# Altium schematic sheet FaultCircuit.SchDoc(FaultCircuit)
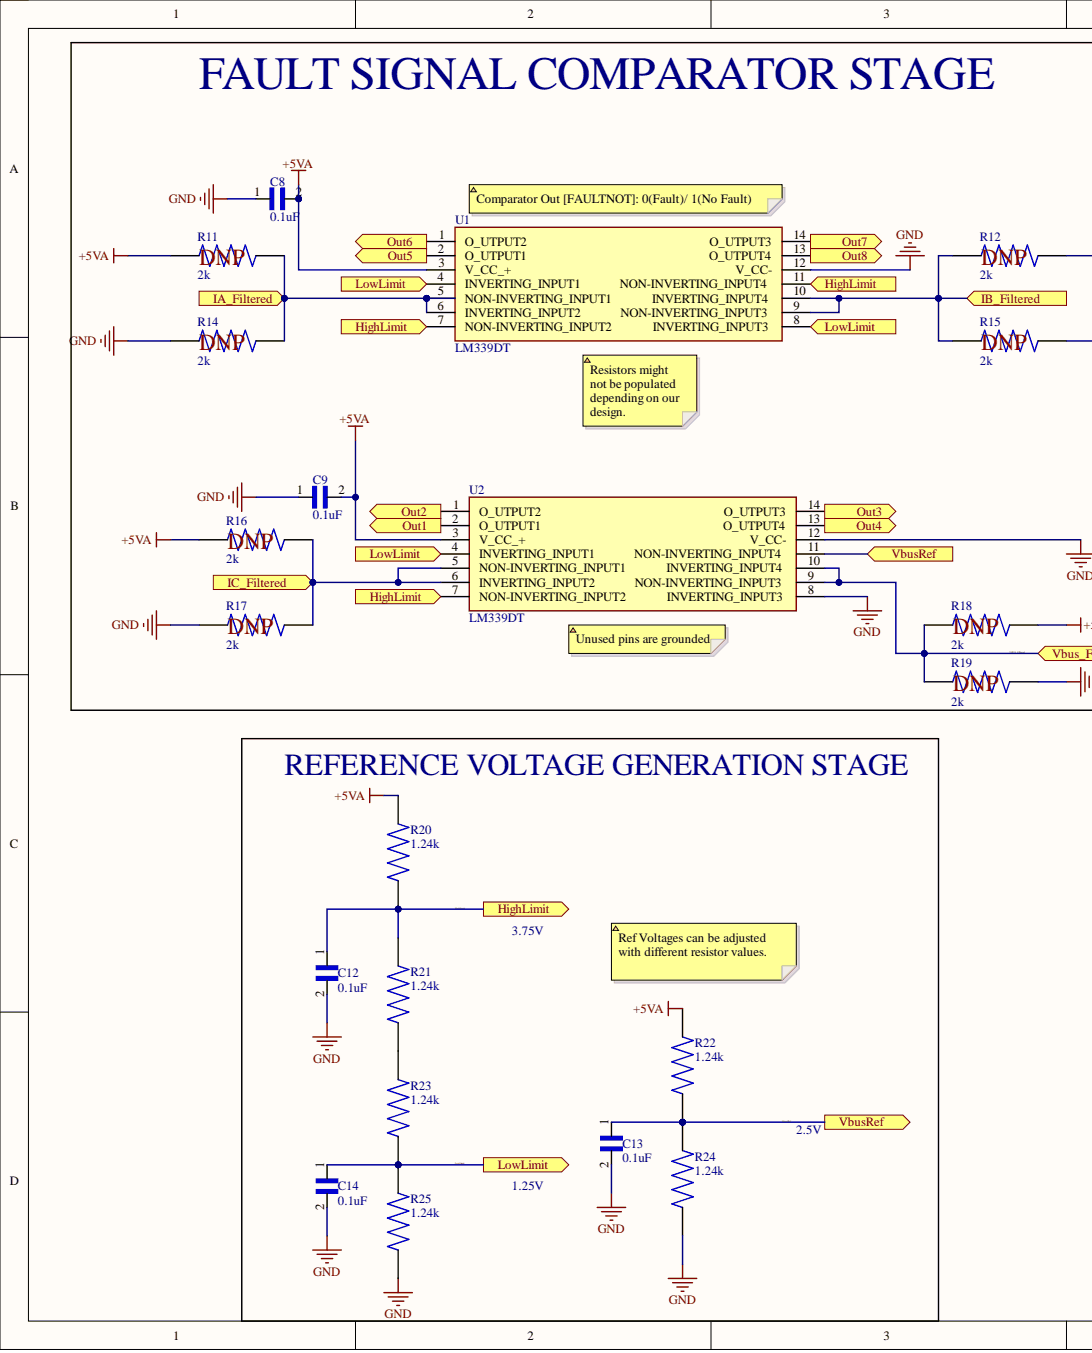
[diagram p0: FaultCircuit.SchDoc, left]
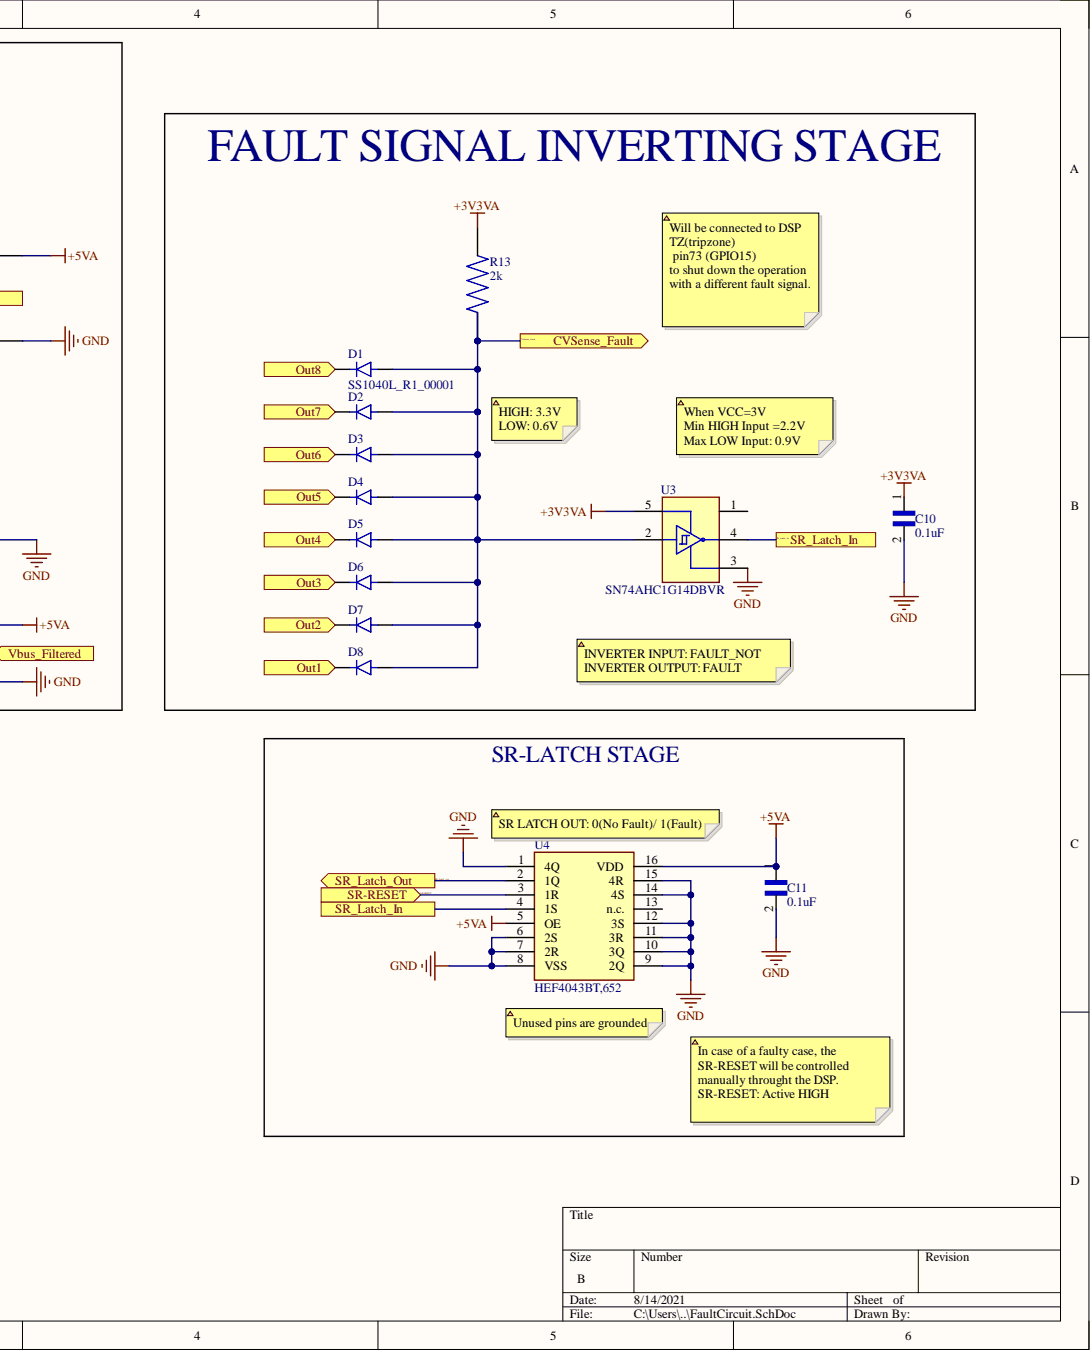
[diagram p1: FaultCircuit.SchDoc, right]

## Parts
C8  0.1uF  [CAP 0805(2012 metric)]
C9  0.1uF  [CAP 0805(2012 metric)]
C10  0.1uF  [CAP 0805(2012 metric)]
C11  0.1uF  [CAP 0805(2012 metric)]
C12  0.1uF  [CAP 0805(2012 metric)]
C13  0.1uF  [CAP 0805(2012 metric)]
C14  0.1uF  [CAP 0805(2012 metric)]
D1  SS1040L_R1_00001  [SOD123]
D2  SS1040L_R1_00001  [SOD123]
D3  SS1040L_R1_00001  [SOD123]
D4  SS1040L_R1_00001  [SOD123]
D5  SS1040L_R1_00001  [SOD123]
D6  SS1040L_R1_00001  [SOD123]
D7  SS1040L_R1_00001  [SOD123]
D8  SS1040L_R1_00001  [SOD123]
R11  2k  [RES 0805(2012 metric)]
R12  2k  [RES 0805(2012 metric)]
R13  2k  [RES 0805(2012 metric)]
R14  2k  [RES 0805(2012 metric)]
R15  2k  [RES 0805(2012 metric)]
R16  2k  [RES 0805(2012 metric)]
R17  2k  [RES 0805(2012 metric)]
R18  2k  [RES 0805(2012 metric)]
R19  2k  [RES 0805(2012 metric)]
R20  1.24k  [RES 0805(2012 metric)]
R21  1.24k  [RES 0805(2012 metric)]
R22  1.24k  [RES 0805(2012 metric)]
R23  1.24k  [RES 0805(2012 metric)]
R24  1.24k  [RES 0805(2012 metric)]
R25  1.24k  [RES 0805(2012 metric)]
U1  LM339DT  [SOIC127P600X175-14N]
U2  LM339DT  [SOIC127P600X175-14N]
U3  SN74AHC1G14DBVR  [SN74AHC1G14DBVR]
U4  HEF4043BT,652  [SOIC127P600X175-16N]

## Ports
CVSense_Fault, HighLimit, IA_Filtered, IB_Filtered, IC_Filtered, LowLimit, Out1, Out2, Out3, Out4, Out5, Out6, Out7, Out8, SR-RESET, SR_Latch_In, SR_Latch_Out, Vbus_Filtered, VbusRef

## Nets
+3V3VA: C10.1 R13.2 U3.5
+5VA: C8.2 C9.2 C11.1 R11.1 R12.2 R16.1 R18.2 R20.2 R22.2 U1.3(V_CC_+) U2.3(V_CC_+) U4.5(OE) U4.16(VDD)
GND: C8.1 C9.1 C10.2 C11.2 C12.2 C13.2 C14.2 R14.1 R15.2 R17.1 R19.2 R24.1 R25.1 U1.12(V_CC-) U2.8(INVERTING_INPUT3) U2.12(V_CC-) U3.3 U4.1(4Q) U4.6(2S) U4.7(2R) U4.8(VSS) U4.9(2Q) U4.10(3Q) U4.11(3R) U4.12(3S) U4.14(4S) U4.15(4R)
CVSense_Fault: D1.2 D2.2 D3.2 D4.2 D5.2 D6.2 D7.2 D8.2 R13.1 U3.2 ; port CVSense_Fault
HighLimit: C12.1 R20.1 R21.2 U1.7(NON-INVERTING_INPUT2) U1.11(NON-INVERTING_INPUT4) U2.7(NON-INVERTING_INPUT2) ; port HighLimit
IA_Filtered: R11.2 R14.2 U1.5(NON-INVERTING_INPUT1) U1.6(INVERTING_INPUT2) ; port IA_Filtered
IB_Filtered: R12.1 R15.1 U1.9(NON-INVERTING_INPUT3) U1.10(INVERTING_INPUT4) ; port IB_Filtered
IC_Filtered: R16.2 R17.2 U2.5(NON-INVERTING_INPUT1) U2.6(INVERTING_INPUT2) ; port IC_Filtered
LowLimit: C14.1 R23.1 R25.2 U1.4(INVERTING_INPUT1) U1.8(INVERTING_INPUT3) U2.4(INVERTING_INPUT1) ; port LowLimit
Out1: D8.1 U2.2(O_UTPUT1) ; port Out1
Out2: D7.1 U2.1(O_UTPUT2) ; port Out2
Out3: D6.1 U2.14(O_UTPUT3) ; port Out3
Out4: D5.1 U2.13(O_UTPUT4) ; port Out4
Out5: D4.1 U1.2(O_UTPUT1) ; port Out5
Out6: D3.1 U1.1(O_UTPUT2) ; port Out6
Out7: D2.1 U1.14(O_UTPUT3) ; port Out7
Out8: D1.1 U1.13(O_UTPUT4) ; port Out8
SR-RESET: U4.3(1R) ; port SR-RESET
SR_Latch_In: U3.4 U4.4(1S) ; port SR_Latch_In
SR_Latch_out: U4.2(1Q) ; port SR_Latch_Out
VBUS_FIltered: R18.1 R19.1 U2.9(NON-INVERTING_INPUT3) U2.10(INVERTING_INPUT4) ; port Vbus_Filtered
VbusRef: C13.1 R22.1 R24.2 U2.11(NON-INVERTING_INPUT4) ; port VbusRef
NetR21_1: R21.1 R23.2

Not connected: U3 1; U4 13(n.c.)

## Library data of the parts
C8-C14 = 0.1uF: 0.1µF ±10% 25V Ceramic Capacitor X7R 0805; Component Kind: Standard; Library Name: 0.1uF 25 V 0805.SchLib
R11-R19 = 2k 0.1W: 2 kOhms ±1% 0.1W, 1/10W Chip Resistor 0603 (1608 Metric) Moisture Resistant Thick Film; Component Kind: Standard; Library Name: 2k 0.1W 0805.SchLib
R20-R25 = 1.24k 0805: Component Kind: Standard; Description: 1.24k 0805; Library Name: 1.24k 0805.SchLib
U1 U2 = LM339DT: Integrated Circuit; Manufacturer_Name: STMicroelectronics; Arrow Part Number: LM339DT; Arrow Price/Stock: https://www.arrow.com/en/products/lm339dt/stmicroelectronics; Component Kind: Standard; Datasheet Link: http://www.st.com/st-web-ui/static/active/en/resource/technical/document/datasheet/CD00000460.pdf; Height: 1.75mm; Manufacturer_Part_Number: LM339DT; Mouser Part Number: 511-LM339DT; Mouser Price/Stock: https://www.mouser.co.uk/ProductDetail/STMicroelectronics/LM339DT?qs=8q6yV%252BexmWU1LP6UrPvZEQ%3D%3D; Supplier 1: Avnet; Supplier Part Number 1: LM339DTBR2G
U3 = SN74AHC1G14DBVR: Inverter; Component Kind: Standard; Library Name: SN74AHC1G14DBVR.SchLib
U4 = HEF4043BT,652: Integrated Circuit; Manufacturer_Name: Nexperia; Arrow Part Number: HEF4043BT,652; Arrow Price/Stock: https://www.arrow.com/en/products/hef4043bt652/nexperia; Component Kind: Standard; Datasheet Link: https://assets.nexperia.com/documents/data-sheet/HEF4043B.pdf; Height: 1.75mm; Manufacturer_Part_Number: HEF4043BT,652; Mouser Part Number: 771-HEF4043BT652; Mouser Price/Stock: https://www.mouser.com/Search/Refine.aspx?Keyword=771-HEF4043BT652
D1-D8 = SS1040L_R1_00001: Component Kind: Standard; Library Name: SS1040L_R1_00001.SchLib
